# Altium schematic sheet Socket.SchDoc
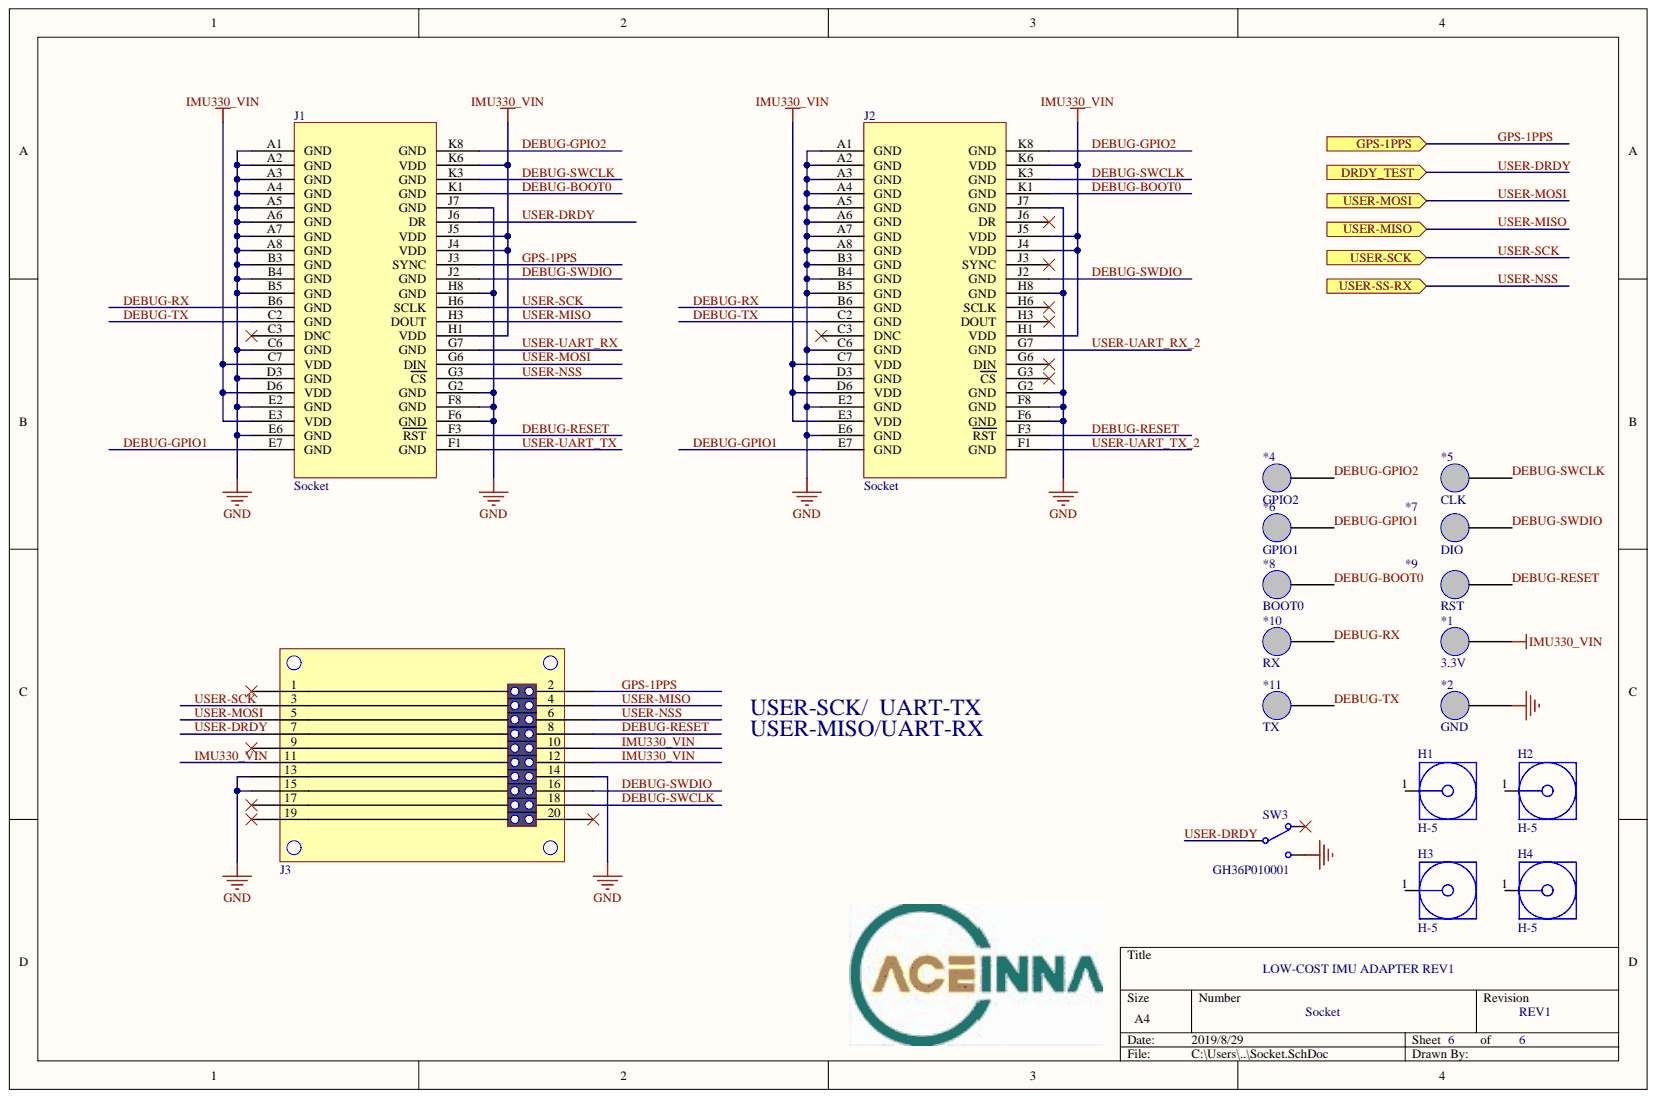
[diagram p0: Socket.SchDoc]

## Parts
*1  3.3V  [PAD-1.5]
*2  GND  [PAD-1.5]
*4  GPIO2  [PAD-1.5]
*5  CLK  [PAD-1.5]
*6  GPIO1  [PAD-1.5]
*7  DIO  [PAD-1.5]
*8  BOOT0  [PAD-1.5]
*9  RST  [PAD-1.5]
*10  RX  [PAD-1.5]
*11  TX  [PAD-1.5]
H1  H-5  [Hole]
H2  H-5  [Hole]
H3  H-5  [Hole]
H4  H-5  [Hole]
J1  Socket  [Socket_BGA44]
J2  Socket  [Socket_BGA44]
J3  CLM-110-02-L-D  [CON-20P-1.00MM-SMD-DULE FEMALE - duplicate]  (value not drawn)
SW3  GH36P010001  [GH39P010001]

## Ports
DRDY_TEST, GPS-1PPS, USER-MISO, USER-MOSI, USER-SCK, USER-SS-RX

## Nets
GND: *2.1 J1.A1(GND) J1.A2(GND) J1.A3(GND) J1.A4(GND) J1.A5(GND) J1.A6(GND) J1.A7(GND) J1.A8(GND) J1.B3(GND) J1.B4(GND) J1.B5(GND) J1.C6(GND) J1.D3(GND) J1.E2(GND) J1.E6(GND) J1.F6(GND) J1.F8(GND) J1.G2(GND) J1.H8(GND) J1.J7(GND) J2.A1(GND) J2.A2(GND) J2.A3(GND) J2.A4(GND) J2.A5(GND) J2.A6(GND) J2.A7(GND) J2.A8(GND) J2.B3(GND) J2.B4(GND) J2.B5(GND) J2.C6(GND) J2.D3(GND) J2.E2(GND) J2.E6(GND) J2.F6(GND) J2.F8(GND) J2.G2(GND) J2.H8(GND) J2.J7(GND) J3.13 J3.14 J3.15 SW3.3
DEBUG-BOOT0: *8.1 J1.K1(GND) J2.K1(GND)
DEBUG-GPIO1: *6.1 J1.E7(GND) J2.E7(GND)
DEBUG-GPIO2: *4.1 J1.K8(GND) J2.K8(GND)
DEBUG-RESET: *9.1 J1.F3(RST) J2.F3(RST) J3.8
DEBUG-RX: *10.1 J1.B6(GND) J2.B6(GND)
DEBUG-SWCLK: *5.1 J1.K3(GND) J2.K3(GND) J3.18
DEBUG-SWDIO: *7.1 J1.J2(GND) J2.J2(GND) J3.16
DEBUG-TX: *11.1 J1.C2(GND) J2.C2(GND)
GPS-1PPS: J1.J3(SYNC) J3.2 ; port GPS-1PPS
IMU330_VIN: *1.1 J1.C7(VDD) J1.D6(VDD) J1.E3(VDD) J1.H1(VDD) J1.J4(VDD) J1.J5(VDD) J1.K6(VDD) J2.C7(VDD) J2.D6(VDD) J2.E3(VDD) J2.H1(VDD) J2.J4(VDD) J2.J5(VDD) J2.K6(VDD) J3.10 J3.11 J3.12
USER-DRDY: J1.J6(DR) J3.7 SW3.2 ; port DRDY_TEST
USER-MISO: J1.H3(DOUT) J3.4 ; port USER-MISO
USER-MOSI: J1.G6(DIN) J3.5 ; port USER-MOSI
USER-NSS: J1.G3(CS) J3.6 ; port USER-SS-RX
USER-SCK: J1.H6(SCLK) J3.3 ; port USER-SCK
USER-UART_RX: J1.G7(GND)
USER-UART_RX_2: J2.G7(GND)
USER-UART_TX: J1.F1(GND)
USER-UART_TX_2: J2.F1(GND)

Not connected: H1 1; H2 1; H3 1; H4 1; J1 C3(DNC); J2 C3(DNC) G3(CS) G6(DIN) H3(DOUT) H6(SCLK) J3(SYNC) J6(DR); J3 1 9 17 19 20; SW3 1

## Library data of the parts
*1 *2 *4-*11 = PAD: Component Kind: Standard; Library Name: General.SchLib
H1-H4 = H-screw: Hole 5/8; Component Kind: Standard; Library Name: 机械结构件.SchLib
J1 J2 = connector: IMU180_Customized_Socket; Component Kind: Standard; Library Name: Connector.SchLib
J3 = CON380-2*10: CONN, SOCKET, RCPT, 2X10P, 1mm, CLM-110-02-L-D, -55℃~125℃, RoHS; PRIMARY MANUFACTURER: SAMTEC; Component Kind: Standard; Library Name: 连接器.SchLib; PRICE: PRICE; PRIMARY MPN: CLM-110-02-L-D
SW3 = SWITCH-SPST: Switch; Component Kind: Standard; Library Name: General.SchLib
